# Altium schematic sheet Charging_TI.SchDoc(Charging_TI)
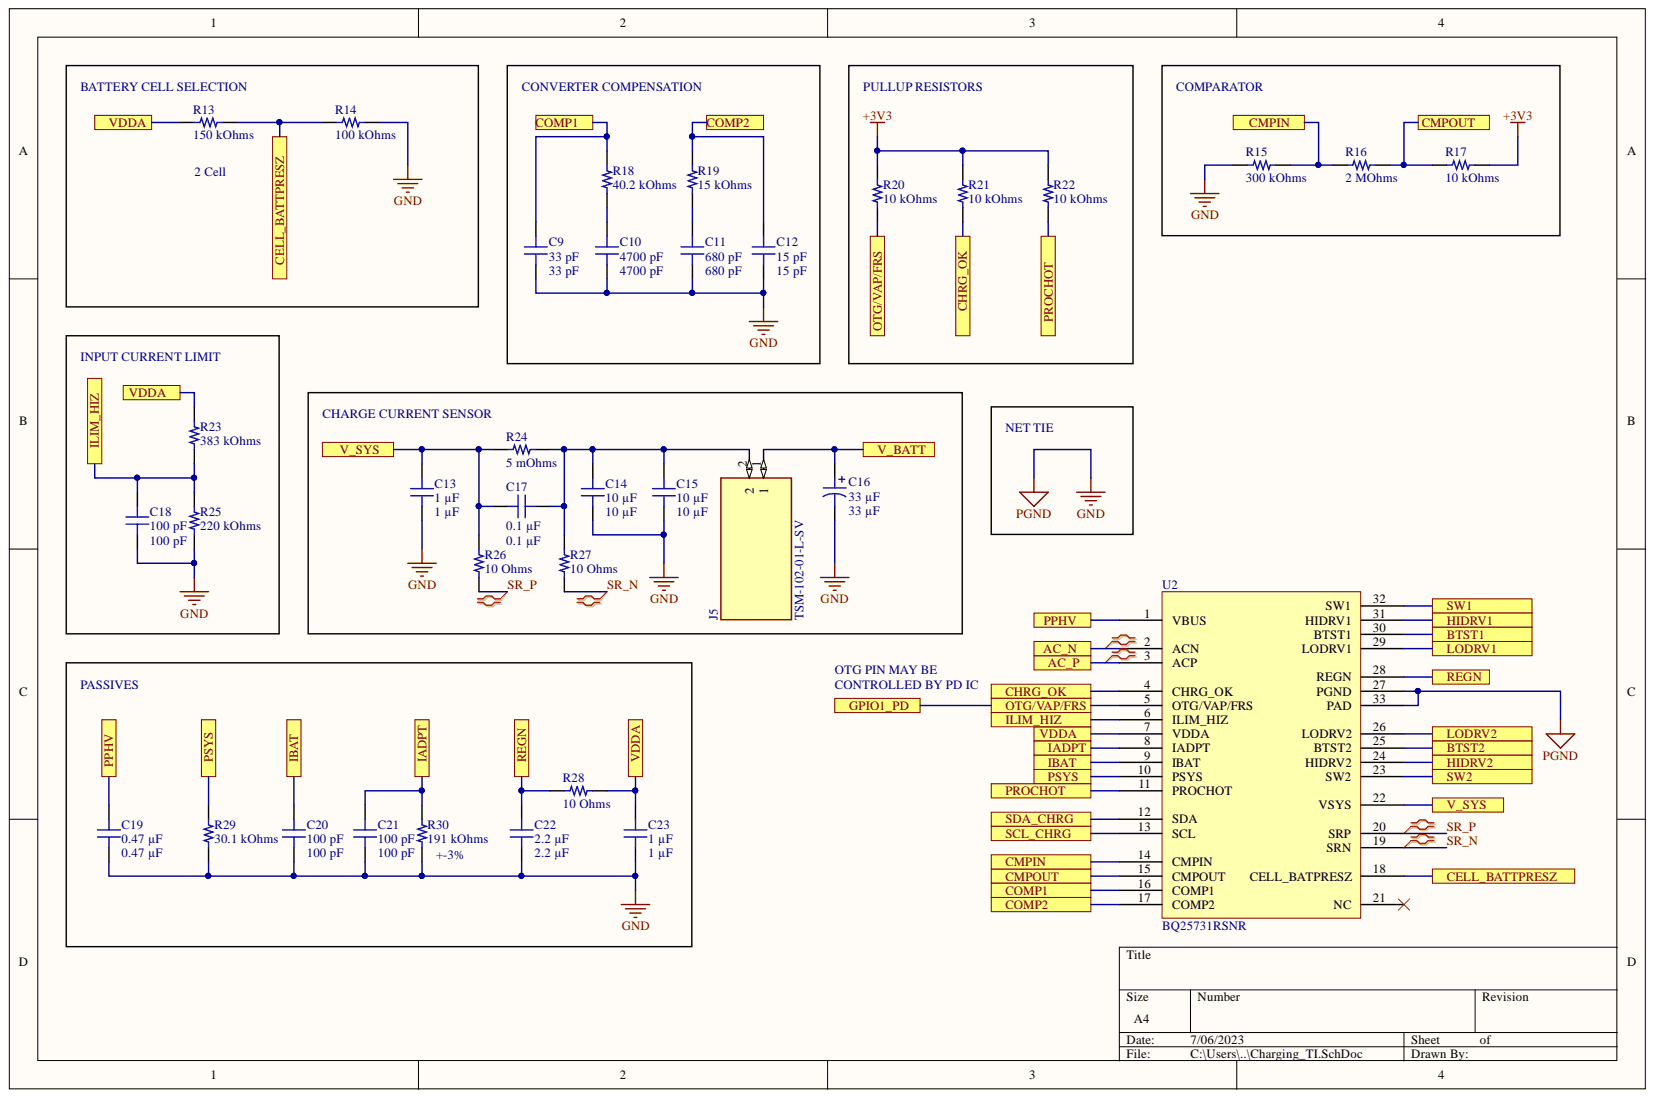
[diagram p0: Charging_TI.SchDoc]

## Parts
C9  33 pF  [CAP 0402_1005]
C10  4700 pF  [CAP 0402_1005]
C11  680 pF  [CAP 0402_1005]
C12  15 pF  [CAP 0402_1005]
C13  1 µF  [CAP 0402_1005]
C14  10 µF  [CAP 0805_2012]
C15  10 µF  [CAP 0805_2012]
C16  33 µF  [CAP POL 2917_7343]
C17  0.1 µF  [CAP 0603_1608]
C18  100 pF  [CAP 0603_1608]
C19  0.47 µF  [CAP 0805_2012]
C20  100 pF  [CAP 0603_1608]
C21  100 pF  [CAP 0603_1608]
C22  2.2 µF  [CAP 0603_1608]
C23  1 µF  [CAP 0603_1608]
J5  TSM-102-01-L-SV  [TSM-102-01-L-SV]
R13  150 kOhms  [RES 0402_1005]
R14  100 kOhms  [RES 0402_1005]
R15  300 kOhms  [RES 0402_1005]
R16  2 MOhms  [RES 0402_1005]
R17  10 kOhms  [RES 0402_1005]
R18  40.2 kOhms  [RES 0402_1005]
R19  15 kOhms  [RES 0402_1005]
R20  10 kOhms  [RES 0402_1005]
R21  10 kOhms  [RES 0402_1005]
R22  10 kOhms  [RES 0402_1005]
R23  383 kOhms  [RES 0402_1005]
R24  5 mOhms  [RES 1206_3216]
R25  220 kOhms  [RES 0402_1005]
R26  10 Ohms  [RES 0603_1608]
R27  10 Ohms  [RES 0603_1608]
R28  10 Ohms  [RES 0603_1608]
R29  30.1 kOhms  [RES 0402_1005]
R30  191 kOhms  [RES 0402_1005]
U2  BQ25731RSNR  [QFN40P400X400X80_HS-33N]

## Ports
AC_N, AC_P, BTST1, BTST2, CELL_BATTPRESZ, CHRG_OK, CMPIN, CMPOUT, COMP1, COMP2, GPIO1_PD, HIDRV1, HIDRV2, IADPT, IBAT, ILIM_HIZ, LODRV1, LODRV2, OTG/VAP/FRS, PPHV, PROCHOT, PSYS, REGN, SCL_CHRG, SDA_CHRG, SW1, SW2, V_BATT, V_SYS, VDDA

## Nets
+3V3: R17.1 R20.2 R21.2 R22.2
GND: C9.1 C10.1 C11.1 C12.1 C13.2 C14.1 C15.1 C16.2 C18.1 C19.1 C20.1 C21.1 C22.1 C23.1 R14.2 R15.1 R25.1 R29.1 R30.1 U2.27(PGND) U2.33(PAD)
AC_N: U2.2(ACN) ; port AC_N
AC_P: U2.3(ACP) ; port AC_P
BTST1: U2.30(BTST1) ; port BTST1
BTST2: U2.25(BTST2) ; port BTST2
CELL_BATTPRESZ: R13.2 R14.1 U2.18(CELL_BATPRESZ) ; port CELL_BATTPRESZ
CHRG_OK: R21.1 U2.4(CHRG_OK) ; port CHRG_OK
CMPIN: R15.2 R16.1 U2.14(CMPIN) ; port CMPIN
CMPOUT: R16.2 R17.2 U2.15(CMPOUT) ; port CMPOUT
COMP1: C9.2 R18.2 U2.16(COMP1) ; port COMP1
COMP2: C12.2 R19.2 U2.17(COMP2) ; port COMP2
GPIO1_PD: R20.1 U2.5(OTG/VAP/FRS) ; port GPIO1_PD, port OTG/VAP/FRS
HIDRV1: U2.31(HIDRV1) ; port HIDRV1
HIDRV2: U2.24(HIDRV2) ; port HIDRV2
IADPT: C21.2 R30.2 U2.8(IADPT) ; port IADPT
IBAT: C20.2 U2.9(IBAT) ; port IBAT
ILIM_HIZ: C18.2 R23.1 R25.2 U2.6(ILIM_HIZ) ; port ILIM_HIZ
LODRV1: U2.29(LODRV1) ; port LODRV1
LODRV2: U2.26(LODRV2) ; port LODRV2
PPHV: C19.2 U2.1(VBUS) ; port PPHV
PROCHOT: R22.1 U2.11(PROCHOT) ; port PROCHOT
PSYS: R29.2 U2.10(PSYS) ; port PSYS
REGN: C22.2 R28.1 U2.28(REGN) ; port REGN
SCL_CHRG: U2.13(SCL) ; port SCL_CHRG
SDA_CHRG: U2.12(SDA) ; port SDA_CHRG
SR_N: R27.1 U2.19(SRN)
SR_P: R26.1 U2.20(SRP)
SW1: U2.32(SW1) ; port SW1
SW2: U2.23(SW2) ; port SW2
V_BATT: C16.1 J5.1 ; port V_BATT
V_SYS: C13.1 C17.1 R24.1 R26.2 U2.22(VSYS) ; port V_SYS
VDDA: C23.2 R13.1 R23.2 R28.2 U2.7(VDDA) ; port VDDA
NetC10_2: C10.2 R18.1
NetC11_2: C11.2 R19.1
NetC14_2: C14.2 C15.2 C17.2 J5.2 R24.2 R27.2

Not connected: U2 21(NC)

## Library data of the parts
C9 = UMK105CH330JVHF: CAP CER 33PF 50V CH 0402; manufacturer: Taiyo Yuden; Tolerance: ±5%; Database Table Name: Capacitors - Ceramic - 0402; Voltage - Rated: 50V; Value: 33 pF; Temperature Coefficient: CH; Supplier Part Number 1: 587-5079-1-ND; Supplier 1: DigiKey; Size / Dimension: 0.039" L x 0.020" W (1.00mm x 0.50mm); series: M; Ratings: AEC-Q200; price: 0.01; Part Status: Active; Part Number: UMK105CH330JVHF; partid: 1883; packaging: TapeAndReel; Package / Case: 0402 (1005 Metric); Mounting Type: Surface Mount, MLCC; Minimum Order: 1; Lifecycle Status: Active; Library Name: Celestial Altium Library - altium_library.DbLib; lastupdated: 14/08/2022 9:05:56 PM; Device Package: 0402 (1005 Metric); componentlink2url: https://www.digikey.com/en/products/detail/taiyo-yuden/UMK105CH330JVHF/6563672; componentlink2description: DigiKey Link; Component Kind: Standard; Capacitance: 33 pF; Applications: Automotive
C10 = 0402B472J500CT: CAP CER 4700PF 50V X7R 0402; manufacturer: Walsin Technology Corporation; Tolerance: ±5%; Database Table Name: Capacitors - Ceramic - 0402; Voltage - Rated: 50V; Value: 4700 pF; Thickness (Max): 0.022" (0.55mm); Temperature Coefficient: X7R; Supplier Part Number 1: 1292-1210-1-ND; Supplier 1: DigiKey; Size / Dimension: 0.039" L x 0.020" W (1.00mm x 0.50mm); series: -; price: 0.01; Part Status: Active; Part Number: 0402B472J500CT; partid: 1879; packaging: TapeAndReel; Package / Case: 0402 (1005 Metric); Operating Temperature: -55°C ~ 125°C; Mounting Type: Surface Mount, MLCC; Minimum Order: 1; Lifecycle Status: Active; Library Name: Celestial Altium Library - altium_library.DbLib; lastupdated: 14/08/2022 9:05:56 PM; Device Package: 0402 (1005 Metric); componentlink2url: https://www.digikey.com/en/products/detail/walsin-technology-corporation/0402B472J500CT/9354708; componentlink2description: DigiKey Link; componentlink1url: http://www.passivecomponent.com/wp-content/uploads/2018/10/MLCC.pdf; componentlink1description: Datasheet; Component Kind: Standard; Capacitance: 4700 pF; Applications: General Purpose
C11 = C1005NP01H681J050BA: CAP CER 680PF 50V NP0 0402; manufacturer: TDK Corporation; Tolerance: ±5%; Temperature Coefficient: C0G, NP0; Database Table Name: Capacitors - Ceramic - 0402; Voltage - Rated: 50V; Value: 680 pF; Thickness (Max): 0.022" (0.55mm); Supplier Part Number 1: 445-13809-1-ND; Supplier 1: DigiKey; Size / Dimension: 0.039" L x 0.020" W (1.00mm x 0.50mm); series: C; price: 0.02; Part Status: Active; Part Number: C1005NP01H681J050BA; partid: 1790; packaging: TapeAndReel; Package / Case: 0402 (1005 Metric); Operating Temperature: -55°C ~ 150°C; Mounting Type: Surface Mount, MLCC; Minimum Order: 1; Lifecycle Status: Active; Library Name: Celestial Altium Library - altium_library.DbLib; lastupdated: 14/08/2022 9:05:57 PM; Features: High Temperature; Device Package: 0402 (1005 Metric); componentlink2url: https://www.digikey.com/en/products/detail/tdk-corporation/C1005NP01H681J050BA/3951045; componentlink2description: DigiKey Link; componentlink1url: https://product.tdk.com/info/en/catalog/datasheets/mlcc_commercial_hightemp_en.pdf?ref_disty=digikey; componentlink1description: Datasheet; Component Kind: Standard; Capacitance: 680 pF; Applications: General Purpose
C12 = CBR04C150J5GAC: CAP CER 15PF 50V C0G/NP0 0402; manufacturer: KEMET; Tolerance: ±5%; Temperature Coefficient: C0G, NP0; Database Table Name: Capacitors - Ceramic - 0402; Voltage - Rated: 50V; Value: 15 pF; Thickness (Max): 0.022" (0.55mm); Supplier Part Number 1: 399-6170-1-ND; Supplier 1: DigiKey; Size / Dimension: 0.039" L x 0.020" W (1.00mm x 0.50mm); series: CBR-SMD RF C0G; price: 0.02; Part Status: Active; Part Number: CBR04C150J5GAC; partid: 1728; packaging: TapeAndReel; Package / Case: 0402 (1005 Metric); Operating Temperature: -55°C ~ 125°C; Mounting Type: Surface Mount, MLCC; Minimum Order: 1; Lifecycle Status: Active; Library Name: Celestial Altium Library - altium_library.DbLib; lastupdated: 14/08/2022 9:05:58 PM; Features: High Q, Low Loss, Ultra Low ESR; Device Package: 0402 (1005 Metric); componentlink2url: https://www.digikey.com/en/products/detail/kemet/CBR04C150J5GAC/2732287; componentlink2description: DigiKey Link; componentlink1url: https://api.kemet.com/component-edge/download/datasheet/CBR04C150J5GAC.pdf; componentlink1description: Datasheet; Component Kind: Standard; Capacitance: 15 pF; Applications: RF, Microwave, High Frequency
C13 = EMK105BJ105MV-F: CAP CER 1UF 16V X5R 0402; manufacturer: Taiyo Yuden; Tolerance: ±20%; Database Table Name: Capacitors - Ceramic - 0402; Voltage - Rated: 16V; Value: 1 µF; Thickness (Max): 0.022" (0.55mm); Temperature Coefficient: X5R; Supplier Part Number 1: 587-5514-1-ND; Supplier 1: DigiKey; Size / Dimension: 0.039" L x 0.020" W (1.00mm x 0.50mm); series: M; price: 0.01; Part Status: Active; Part Number: EMK105BJ105MV-F; partid: 1933; packaging: TapeAndReel; Package / Case: 0402 (1005 Metric); Operating Temperature: -55°C ~ 85°C; Mounting Type: Surface Mount, MLCC; Minimum Order: 1; Lifecycle Status: Active; Library Name: Celestial Altium Library - altium_library.DbLib; lastupdated: 5/04/2023 7:05:02 PM; Device Package: 0402 (1005 Metric); componentlink2url: https://www.digikey.com/en/products/detail/taiyo-yuden/EMK105BJ105MV-F/4970641; componentlink2description: DigiKey Link; componentlink1url: https://ds.yuden.co.jp/TYCOMPAS/ut/detail?pn=EMK105BJ105MV-F  &u=M; componentlink1description: Datasheet; Component Kind: Standard; Capacitance: 1 µF; Applications: SMPS Filtering
C14 C15 = GRM21BR61H106KE43L: CAP CER 10UF 50V X5R 0805; manufacturer: Murata Electronics; Tolerance: ±10%; Database Table Name: Capacitors - Ceramic - 0805; Voltage - Rated: 50V; Value: 10 µF; Thickness (Max): 0.057" (1.45mm); Temperature Coefficient: X5R; Supplier Part Number 1: 490-18663-1-ND; Supplier 1: DigiKey; Size / Dimension: 0.079" L x 0.049" W (2.00mm x 1.25mm); series: GRM; price: 0.07; Part Status: Active; Part Number: GRM21BR61H106KE43L; partid: 39284; packaging: TapeAndReel; Package / Case: 0805 (2012 Metric); Operating Temperature: -55°C ~ 85°C; Mounting Type: Surface Mount, MLCC; Minimum Order: 1; Lifecycle Status: Active; Library Name: Celestial Altium Library - altium_library.DbLib; lastupdated: 14/08/2022 9:12:57 PM; Device Package: 0805 (2012 Metric); componentlink2url: https://www.digikey.com/en/products/detail/murata-electronics/GRM21BR61H106KE43L/10326316; componentlink2description: DigiKey Link; componentlink1url: https://search.murata.co.jp/Ceramy/image/img/A01X/G101/ENG/GRM21BR61H106KE43-01A.pdf; componentlink1description: Datasheet; Component Kind: Standard; Capacitance: 10 µF; Applications: General Purpose
C16 = T495X336M035ATE175: CAP TANT 33UF 20% 35V 2917; Manufacturer Size Code: X; manufacturer: KEMET; Type: Molded; Lifetime @ Temp.: 2000 Hrs @ 85°C; ESR (Equivalent Series Resistance): 175mOhm; Database Table Name: Capacitors - Tantalum; Voltage - Rated: 35 V; Value: 33 µF; Tolerance: ±20%; Supplier Part Number 1: 399-5266-1-ND; Supplier 1: DigiKey; Size / Dimension: 0.287" L x 0.169" W (7.30mm x 4.30mm); series: T495; price: 1.07; Part Status: Active; Part Number: T495X336M035ATE175; partid: 698; packaging: TapeAndReel; Package / Case: 2917 (7343 Metric); Operating Temperature: -55°C ~ 125°C; Mounting Type: Surface Mount; Minimum Order: 1; Lifecycle Status: Active; Library Name: Celestial Altium Library - altium_library.DbLib; lastupdated: 14/08/2022 9:47:02 PM; Height - Seated (Max): 0.169" (4.30mm); Features: General Purpose; Device Package: 2917 (7343 Metric); componentlink2url: https://www.digikey.com/en/products/detail/kemet/T495X336M035ATE175/1833502; componentlink2description: DigiKey Link; componentlink1url: https://api.kemet.com/component-edge/download/datasheet/T495X336M035ATE175.pdf; componentlink1description: Datasheet; Component Kind: Standard; Capacitance: 33 µF
C17 = C0603C104K5RAC7411: CAP CER 0.1UF 50V X7R 0603; manufacturer: KEMET; Tolerance: ±10%; Database Table Name: Capacitors - Ceramic - 0603; Voltage - Rated: 50V; Value: 0.1 µF; Thickness (Max): 0.035" (0.90mm); Temperature Coefficient: X7R; Supplier Part Number 1: 399-7374-2-ND; Supplier 1: DigiKey; Size / Dimension: 0.063" L x 0.031" W (1.60mm x 0.80mm); series: SMD Comm X7R; price: 0.01; Part Status: Active; Part Number: C0603C104K5RAC7411; partid: 2233; packaging: TapeAndReel; Package / Case: 0603 (1608 Metric); Operating Temperature: -55°C ~ 125°C; Mounting Type: Surface Mount, MLCC; Minimum Order: 15000; Lifecycle Status: Active; Library Name: Celestial Altium Library - altium_library.DbLib; lastupdated: 14/08/2022 9:09:47 PM; Device Package: 0603 (1608 Metric); componentlink2url: https://www.digikey.com/en/products/detail/kemet/C0603C104K5RAC7411/3317013; componentlink2description: DigiKey Link; componentlink1url: https://api.kemet.com/component-edge/download/datasheet/C0603C104K5RAC7411.pdf; componentlink1description: Datasheet; Component Kind: Standard; Capacitance: 0.1 µF; Applications: Bypass, Decoupling
C18 C20 C21 = C0603C101K5GACAUTO: CAP CER 100PF 50V C0G/NP0 0603; manufacturer: KEMET; Tolerance: ±10%; Temperature Coefficient: C0G, NP0; Database Table Name: Capacitors - Ceramic - 0603; Voltage - Rated: 50V; Value: 100 pF; Thickness (Max): 0.034" (0.87mm); Supplier Part Number 1: 399-6843-1-ND; Supplier 1: DigiKey; Size / Dimension: 0.063" L x 0.031" W (1.60mm x 0.80mm); series: SMD Auto C0G; Ratings: AEC-Q200; price: 0.01; Part Status: Active; Part Number: C0603C101K5GACAUTO; partid: 2201; packaging: TapeAndReel; Package / Case: 0603 (1608 Metric); Operating Temperature: -55°C ~ 125°C; Mounting Type: Surface Mount, MLCC; Minimum Order: 1; Lifecycle Status: Active; Library Name: Celestial Altium Library - altium_library.DbLib; lastupdated: 14/08/2022 9:09:47 PM; Features: Low ESL; Device Package: 0603 (1608 Metric); componentlink2url: https://www.digikey.com/en/products/detail/kemet/C0603C101K5GACAUTO/3314842; componentlink2description: DigiKey Link; componentlink1url: https://api.kemet.com/component-edge/download/datasheet/C0603C101K5GACAUTO.pdf; componentlink1description: Datasheet; Component Kind: Standard; Capacitance: 100 pF; Applications: Automotive
C19 = C0805C474K5RACAUTO: CAP CER 0.47UF 50V X7R 0805; manufacturer: KEMET; Tolerance: ±10%; Database Table Name: Capacitors - Ceramic - 0805; Voltage - Rated: 50V; Value: 0.47 µF; Thickness (Max): 0.043" (1.10mm); Temperature Coefficient: X7R; Supplier Part Number 1: 399-6976-1-ND; Supplier 1: DigiKey; Size / Dimension: 0.079" L x 0.049" W (2.00mm x 1.25mm); series: SMD Auto X7R; Ratings: AEC-Q200; price: 0.06; Part Status: Active; Part Number: C0805C474K5RACAUTO; partid: 2727; packaging: TapeAndReel; Package / Case: 0805 (2012 Metric); Operating Temperature: -55°C ~ 125°C; Mounting Type: Surface Mount, MLCC; Minimum Order: 1; Lifecycle Status: Active; Library Name: Celestial Altium Library - altium_library.DbLib; lastupdated: 14/08/2022 9:13:14 PM; Device Package: 0805 (2012 Metric); componentlink2url: https://www.digikey.com/en/products/detail/kemet/C0805C474K5RACAUTO/3314969; componentlink2description: DigiKey Link; componentlink1url: https://api.kemet.com/component-edge/download/datasheet/C0805C474K5RACAUTO.pdf; componentlink1description: Datasheet; Component Kind: Standard; Capacitance: 0.47 µF; Applications: Automotive, Bypass, Decoupling
C22 = GRM188R6YA225KA12D: CAP CER 2.2UF 35V X5R 0603; manufacturer: Murata Electronics; Tolerance: ±10%; Database Table Name: Capacitors - Ceramic - 0603; Voltage - Rated: 35V; Value: 2.2 µF; Thickness (Max): 0.035" (0.90mm); Temperature Coefficient: X5R; Supplier Part Number 1: 490-7204-1-ND; Supplier 1: DigiKey; Size / Dimension: 0.063" L x 0.031" W (1.60mm x 0.80mm); series: GRM; price: 0.03; Part Status: Active; Part Number: GRM188R6YA225KA12D; partid: 11175; packaging: TapeAndReel; Package / Case: 0603 (1608 Metric); Operating Temperature: -55°C ~ 85°C; Mounting Type: Surface Mount, MLCC; Minimum Order: 1; Lifecycle Status: Active; Library Name: Celestial Altium Library - altium_library.DbLib; lastupdated: 14/08/2022 9:09:23 PM; Device Package: 0603 (1608 Metric); componentlink2url: https://www.digikey.com/en/products/detail/murata-electronics/GRM188R6YA225KA12D/3900462; componentlink2description: DigiKey Link; componentlink1url: https://www.murata.com/~/media/webrenewal/support/library/catalog/products/capacitor/mlcc/c02e.ashx?la=en-us; componentlink1description: Datasheet; Component Kind: Standard; Capacitance: 2.2 µF; Applications: General Purpose
C23 = GMJ107CB7105KAHR: CAP CER 1UF 35V X7R 0603; manufacturer: Taiyo Yuden; Tolerance: ±10%; Database Table Name: Capacitors - Ceramic - 0603; Voltage - Rated: 35V; Value: 1 µF; Thickness (Max): 0.035" (0.90mm); Temperature Coefficient: X7R; Supplier Part Number 1: 587-4356-1-ND; Supplier 1: DigiKey; Size / Dimension: 0.063" L x 0.031" W (1.60mm x 0.80mm); series: M; Ratings: AEC-Q200; price: 0.06; Part Status: Active; Part Number: GMJ107CB7105KAHR; partid: 5874; packaging: TapeAndReel; Package / Case: 0603 (1608 Metric); Operating Temperature: -55°C ~ 125°C; Mounting Type: Surface Mount, MLCC; Minimum Order: 1; Lifecycle Status: Active; Library Name: Celestial Altium Library - altium_library.DbLib; lastupdated: 14/08/2022 9:09:37 PM; Features: Soft Termination; Device Package: 0603 (1608 Metric); componentlink2url: https://www.digikey.com/en/products/detail/taiyo-yuden/GMJ107CB7105KAHR/5405535; componentlink2description: DigiKey Link; componentlink1url: https://www.yuden.co.jp/productdata/catalog//mlcc11_hq_e.pdf; componentlink1description: Datasheet; Component Kind: Standard; Capacitance: 1 µF; Applications: Automotive, Boardflex Sensitive
J5 = TSM-102-01-L-SV: MF: {'manufacturer': u'Samtec Inc.'}; Price: None; Package: None; MP: TSM-102-01-L-SV; Component Kind: Standard; Availability: Unavailable
R13 = RC0402JR-07150KL: RES 150K OHM 5% 1/16W 0402; Manufacturer: Yageo; Temperature Coefficient: ±100ppm/°C; Power (Watts): 0.063W, 1/16W; Database Table Name: Resistors - Surface Mount - 0402; Value: 150 kOhms; Tolerance: ±5%; Supplier Part Number 1: 311-150KJRCT-ND; Supplier Device Package: 0402; Supplier 1: DigiKey; Size / Dimension: 0.039" L x 0.020" W (1.00mm x 0.50mm); Series: RC_L; Resistance: 150 kOhms; Price: 0.01; Part Status: Active; Part Number: RC0402JR-07150KL; PartId: 4824; Packaging: CutTape; Package / Case: 0402 (1005 Metric); Operating Temperature: -55°C ~ 155°C; Number of Terminations: 2; Minimum Order: 1; Lifecycle Status: Active; Library Name: Celestial Altium Library - altium_library.DbLib; LastUpdated: 4/04/2023 7:23:01 PM; Height - Seated (Max): 0.016" (0.40mm); Features: Moisture Resistant; Device Package: 0402 (1005 Metric); Composition: Thick Film; ComponentLink2URL: https://www.digikey.com/en/products/detail/yageo/RC0402JR-07150KL/726425; ComponentLink2Description: DigiKey Link; ComponentLink1URL: https://www.yageo.com/upload/media/product/productsearch/datasheet/rchip/PYu-RC_Group_51_RoHS_L_10.pdf; ComponentLink1Description: Datasheet; Component Kind: Standard
R14 = RT0402FRE07100KL: RES SMD 100K OHM 1% 1/16W 0402; Manufacturer: Yageo; Temperature Coefficient: ±50ppm/°C; Power (Watts): 0.063W, 1/16W; Database Table Name: Resistors - Surface Mount - 0402; Value: 100 kOhms; Tolerance: ±1%; Supplier Part Number 1: YAG2307CT-ND; Supplier Device Package: 0402; Supplier 1: DigiKey; Size / Dimension: 0.039" L x 0.020" W (1.00mm x 0.50mm); Series: RT; Resistance: 100 kOhms; Price: 0.01; Part Status: Active; Part Number: RT0402FRE07100KL; PartId: 3190; Packaging: TapeAndReel; Package / Case: 0402 (1005 Metric); Operating Temperature: -55°C ~ 155°C; Number of Terminations: 2; Minimum Order: 1; Lifecycle Status: Active; Library Name: Celestial Altium Library - altium_library.DbLib; LastUpdated: 4/04/2023 7:23:08 PM; Height - Seated (Max): 0.014" (0.35mm); Device Package: 0402 (1005 Metric); Composition: Thin Film; ComponentLink2URL: https://www.digikey.com/en/products/detail/yageo/RT0402FRE07100KL/1071698; ComponentLink2Description: DigiKey Link; ComponentLink1URL: https://www.yageo.com/upload/media/product/productsearch/datasheet/rchip/PYu-RT_1-to-0.01_RoHS_L_11.pdf; ComponentLink1Description: Datasheet; Component Kind: Standard
R15 = RC0402FR-07300KL: RES 300K OHM 1% 1/16W 0402; Manufacturer: Yageo; Temperature Coefficient: ±100ppm/°C; Power (Watts): 0.063W, 1/16W; Database Table Name: Resistors - Surface Mount - 0402; Value: 300 kOhms; Tolerance: ±1%; Supplier Part Number 1: 311-300KLRCT-ND; Supplier Device Package: 0402; Supplier 1: DigiKey; Size / Dimension: 0.039" L x 0.020" W (1.00mm x 0.50mm); Series: RC_L; Resistance: 300 kOhms; Price: 0.01; Part Status: Active; Part Number: RC0402FR-07300KL; PartId: 2649; Packaging: CutTape; Package / Case: 0402 (1005 Metric); Operating Temperature: -55°C ~ 155°C; Number of Terminations: 2; Minimum Order: 1; Lifecycle Status: Active; Library Name: Celestial Altium Library - altium_library.DbLib; LastUpdated: 4/04/2023 7:23:10 PM; Height - Seated (Max): 0.016" (0.40mm); Features: Moisture Resistant; Device Package: 0402 (1005 Metric); Composition: Thick Film; ComponentLink2URL: https://www.digikey.com/en/products/detail/yageo/RC0402FR-07300KL/726588; ComponentLink2Description: DigiKey Link; ComponentLink1URL: https://www.yageo.com/upload/media/product/productsearch/datasheet/rchip/PYu-RC_Group_51_RoHS_L_10.pdf; ComponentLink1Description: Datasheet; Component Kind: Standard
R16 = RMCF0402JT2M00: RES 2M OHM 5% 1/16W 0402; Manufacturer: Stackpole Electronics Inc; Temperature Coefficient: ±200ppm/°C; Power (Watts): 0.063W, 1/16W; Database Table Name: Resistors - Surface Mount - 0402; Value: 2 MOhms; Tolerance: ±5%; Supplier Part Number 1: 738-RMCF0402JT2M00CT-ND; Supplier Device Package: 0402; Supplier 1: DigiKey; Size / Dimension: 0.039" L x 0.020" W (1.00mm x 0.50mm); Series: RMCF; Resistance: 2 MOhms; Ratings: AEC-Q200; Price: 0.01; Part Status: Active; Part Number: RMCF0402JT2M00; PartId: 2925; Packaging: CutTape; Package / Case: 0402 (1005 Metric); Operating Temperature: -55°C ~ 155°C; Number of Terminations: 2; Minimum Order: 1; Lifecycle Status: Active; Library Name: Celestial Altium Library - altium_library.DbLib; LastUpdated: 4/04/2023 7:23:09 PM; Height - Seated (Max): 0.016" (0.40mm); Features: Automotive AEC-Q200; Device Package: 0402 (1005 Metric); Composition: Thick Film; ComponentLink2URL: https://www.digikey.com/en/products/detail/stackpole-electronics-inc/RMCF0402JT2M00/1712425; ComponentLink2Description: DigiKey Link; ComponentLink1URL: https://www.seielect.com/catalog/sei-rmcf_rmcp.pdf; ComponentLink1Description: Datasheet; Component Kind: Standard
R17 R20-R22 = RC0402JR-1310KL: RES 10K OHM 5% 1/16W 0402; Manufacturer: Yageo; Temperature Coefficient: ±100ppm/°C; Power (Watts): 0.063W, 1/16W; Database Table Name: Resistors - Surface Mount - 0402; Value: 10 kOhms; Tolerance: ±5%; Supplier Part Number 1: 13-RC0402JR-1310KLTR-ND; Supplier Device Package: 0402; Supplier 1: DigiKey; Size / Dimension: 0.039" L x 0.020" W (1.00mm x 0.50mm); Series: RC_L; Resistance: 10 kOhms; Price: 0; Part Status: Active; Part Number: RC0402JR-1310KL; PartId: 69237; Package / Case: 0402 (1005 Metric); Operating Temperature: -55°C ~ 155°C; Number of Terminations: 2; Minimum Order: 50000; Lifecycle Status: Active; Library Name: Celestial Altium Library - altium_library.DbLib; LastUpdated: 4/04/2023 7:21:31 PM; Height - Seated (Max): 0.016" (0.40mm); Features: Moisture Resistant; Device Package: 0402 (1005 Metric); Composition: Thick Film; ComponentLink2URL: https://www.digikey.com/en/products/detail/yageo/RC0402JR-1310KL/13694115; ComponentLink2Description: DigiKey Link; ComponentLink1URL: https://www.yageo.com/upload/media/product/productsearch/datasheet/rchip/PYu-RC_Group_51_RoHS_L_11.pdf; ComponentLink1Description: Datasheet; Component Kind: Standard
R18 = RC0402FR-0740K2L: RES 40.2K OHM 1% 1/16W 0402; Manufacturer: Yageo; Temperature Coefficient: ±100ppm/°C; Power (Watts): 0.063W, 1/16W; Database Table Name: Resistors - Surface Mount - 0402; Value: 40.2 kOhms; Tolerance: ±1%; Supplier Part Number 1: 311-40.2KLRCT-ND; Supplier Device Package: 0402; Supplier 1: DigiKey; Size / Dimension: 0.039" L x 0.020" W (1.00mm x 0.50mm); Series: RC_L; Resistance: 40.2 kOhms; Price: 0.01; Part Status: Active; Part Number: RC0402FR-0740K2L; PartId: 2526; Packaging: CutTape; Package / Case: 0402 (1005 Metric); Operating Temperature: -55°C ~ 155°C; Number of Terminations: 2; Minimum Order: 1; Lifecycle Status: Active; Library Name: Celestial Altium Library - altium_library.DbLib; LastUpdated: 14/08/2022 9:24:17 PM; Height - Seated (Max): 0.016" (0.40mm); Features: Moisture Resistant; Device Package: 0402 (1005 Metric); Composition: Thick Film; ComponentLink2URL: https://www.digikey.com/en/products/detail/yageo/RC0402FR-0740K2L/726607; ComponentLink2Description: DigiKey Link; ComponentLink1URL: https://www.yageo.com/upload/media/product/productsearch/datasheet/rchip/PYu-RC_Group_51_RoHS_L_10.pdf; ComponentLink1Description: Datasheet; Component Kind: Standard
R19 = RC0402FR-1315KL: RES 15K OHM 1% 1/16W 0402; Manufacturer: Yageo; Temperature Coefficient: ±100ppm/°C; Power (Watts): 0.063W, 1/16W; Database Table Name: Resistors - Surface Mount - 0402; Value: 15 kOhms; Tolerance: ±1%; Supplier Part Number 1: 13-RC0402FR-1315KLTR-ND; Supplier Device Package: 0402; Supplier 1: DigiKey; Size / Dimension: 0.039" L x 0.020" W (1.00mm x 0.50mm); Series: RC_L; Resistance: 15 kOhms; Price: 0; Part Status: Active; Part Number: RC0402FR-1315KL; PartId: 65094; Package / Case: 0402 (1005 Metric); Operating Temperature: -55°C ~ 155°C; Number of Terminations: 2; Minimum Order: 50000; Lifecycle Status: Active; Library Name: Celestial Altium Library - altium_library.DbLib; LastUpdated: 14/08/2022 9:22:52 PM; Height - Seated (Max): 0.016" (0.40mm); Features: Moisture Resistant; Device Package: 0402 (1005 Metric); Composition: Thick Film; ComponentLink2URL: https://www.digikey.com/en/products/detail/yageo/RC0402FR-1315KL/13694190; ComponentLink2Description: DigiKey Link; ComponentLink1URL: https://www.yageo.com/upload/media/product/productsearch/datasheet/rchip/PYu-RC_Group_51_RoHS_L_11.pdf; ComponentLink1Description: Datasheet; Component Kind: Standard
R23 = ERJ-2RKF3833X: RES SMD 383K OHM 1% 1/10W 0402; Manufacturer: Panasonic Electronic Components; Temperature Coefficient: ±100ppm/°C; Power (Watts): 0.1W, 1/10W; Database Table Name: Resistors - Surface Mount - 0402; Value: 383 kOhms; Tolerance: ±1%; Supplier Part Number 1: P383KLCT-ND; Supplier Device Package: 0402; Supplier 1: DigiKey; Size / Dimension: 0.039" L x 0.020" W (1.00mm x 0.50mm); Series: ERJ-2RK; Resistance: 383 kOhms; Ratings: AEC-Q200; Price: 0.01; Part Status: Active; Part Number: ERJ-2RKF3833X; PartId: 3860; Packaging: CutTape; Package / Case: 0402 (1005 Metric); Operating Temperature: -55°C ~ 155°C; Number of Terminations: 2; Minimum Order: 1; Lifecycle Status: Active; Library Name: Celestial Altium Library - altium_library.DbLib; LastUpdated: 4/04/2023 7:23:06 PM; Height - Seated (Max): 0.016" (0.40mm); Features: Automotive AEC-Q200; Device Package: 0402 (1005 Metric); Composition: Thick Film; ComponentLink2URL: https://www.digikey.com/en/products/detail/panasonic-electronic-components/ERJ-2RKF3833X/192331; ComponentLink2Description: DigiKey Link; ComponentLink1URL: https://industrial.panasonic.com/cdbs/www-data/pdf/RDA0000/AOA0000C304.pdf; ComponentLink1Description: Datasheet; Component Kind: Standard
R24 = PMR18EZPJU5L0: RES 0.005 OHM 5% 1W 1206; Manufacturer: Rohm Semiconductor; Temperature Coefficient: ±100ppm/°C; Database Table Name: Resistors - Surface Mount - 1206; Value: 5 mOhms; Tolerance: ±5%; Supplier Part Number 1: RHM.005AMCT-ND; Supplier Device Package: 1206; Supplier 1: DigiKey; Size / Dimension: 0.126" L x 0.063" W (3.20mm x 1.60mm); Series: PMR; Resistance: 5 mOhms; Ratings: AEC-Q200; Price: 0.11; Power (Watts): 1W; Part Status: Active; Part Number: PMR18EZPJU5L0; PartId: 27011; Packaging: TapeAndReel; Package / Case: 1206 (3216 Metric); Operating Temperature: -55°C ~ 155°C; Number of Terminations: 2; Minimum Order: 1; Lifecycle Status: Active; Library Name: Celestial Altium Library - altium_library.DbLib; LastUpdated: 14/08/2022 9:38:16 PM; Height - Seated (Max): 0.017" (0.43mm); Features: Automotive AEC-Q200, Current Sense; Device Package: 1206 (3216 Metric); Composition: Metal Element; ComponentLink2URL: https://www.digikey.com/en/products/detail/rohm-semiconductor/PMR18EZPJU5L0/2094212; ComponentLink2Description: DigiKey Link; ComponentLink1URL: https://www.rohm.com/datasheet/PMR18EZPFV/pmr-e; ComponentLink1Description: Datasheet; Component Kind: Standard
R25 = RC0402FR-07220KL: RES 220K OHM 1% 1/16W 0402; Manufacturer: Yageo; Temperature Coefficient: ±100ppm/°C; Power (Watts): 0.063W, 1/16W; Database Table Name: Resistors - Surface Mount - 0402; Value: 220 kOhms; Tolerance: ±1%; Supplier Part Number 1: 311-220KLRCT-ND; Supplier Device Package: 0402; Supplier 1: DigiKey; Size / Dimension: 0.039" L x 0.020" W (1.00mm x 0.50mm); Series: RC_L; Resistance: 220 kOhms; Price: 0.01; Part Status: Active; Part Number: RC0402FR-07220KL; PartId: 2589; Packaging: CutTape; Package / Case: 0402 (1005 Metric); Operating Temperature: -55°C ~ 155°C; Number of Terminations: 2; Minimum Order: 1; Lifecycle Status: Active; Library Name: Celestial Altium Library - altium_library.DbLib; LastUpdated: 4/04/2023 7:23:10 PM; Height - Seated (Max): 0.016" (0.40mm); Features: Moisture Resistant; Device Package: 0402 (1005 Metric); Composition: Thick Film; ComponentLink2URL: https://www.digikey.com/en/products/detail/yageo/RC0402FR-07220KL/726564; ComponentLink2Description: DigiKey Link; ComponentLink1URL: https://www.yageo.com/upload/media/product/productsearch/datasheet/rchip/PYu-RC_Group_51_RoHS_L_10.pdf; ComponentLink1Description: Datasheet; Component Kind: Standard
R26-R28 = ERJ-3EKF10R0V: RES SMD 10 OHM 1% 1/10W 0603; Manufacturer: Panasonic Electronic Components; Temperature Coefficient: ±100ppm/°C; Power (Watts): 0.1W, 1/10W; Database Table Name: Resistors - Surface Mount - 0603; Value: 10 Ohms; Tolerance: ±1%; Supplier Part Number 1: P10.0HCT-ND; Supplier Device Package: 0603; Supplier 1: DigiKey; Size / Dimension: 0.063" L x 0.031" W (1.60mm x 0.80mm); Series: ERJ-3EK; Resistance: 10 Ohms; Ratings: AEC-Q200; Price: 0.01; Part Status: Active; Part Number: ERJ-3EKF10R0V; PartId: 5647; Packaging: TapeAndReel; Package / Case: 0603 (1608 Metric); Operating Temperature: -55°C ~ 155°C; Number of Terminations: 2; Minimum Order: 1; Lifecycle Status: Active; Library Name: Celestial Altium Library - altium_library.DbLib; LastUpdated: 14/08/2022 9:31:25 PM; Height - Seated (Max): 0.022" (0.55mm); Features: Automotive AEC-Q200; Device Package: 0603 (1608 Metric); Composition: Thick Film; ComponentLink2URL: https://www.digikey.com/en/products/detail/panasonic-electronic-components/ERJ-3EKF10R0V/196065; ComponentLink2Description: DigiKey Link; ComponentLink1URL: https://industrial.panasonic.com/cdbs/www-data/pdf/RDA0000/AOA0000C304.pdf; ComponentLink1Description: Datasheet; Component Kind: Standard
R29 = RC0402FR-0730K1L: RES 30.1K OHM 1% 1/16W 0402; Manufacturer: Yageo; Temperature Coefficient: ±100ppm/°C; Power (Watts): 0.063W, 1/16W; Database Table Name: Resistors - Surface Mount - 0402; Value: 30.1 kOhms; Tolerance: ±1%; Supplier Part Number 1: 311-30.1KLRCT-ND; Supplier Device Package: 0402; Supplier 1: DigiKey; Size / Dimension: 0.039" L x 0.020" W (1.00mm x 0.50mm); Series: RC_L; Resistance: 30.1 kOhms; Price: 0.01; Part Status: Active; Part Number: RC0402FR-0730K1L; PartId: 2546; Packaging: CutTape; Package / Case: 0402 (1005 Metric); Operating Temperature: -55°C ~ 155°C; Number of Terminations: 2; Minimum Order: 1; Lifecycle Status: Active; Library Name: Celestial Altium Library - altium_library.DbLib; LastUpdated: 4/04/2023 7:23:11 PM; Height - Seated (Max): 0.016" (0.40mm); Features: Moisture Resistant; Device Package: 0402 (1005 Metric); Composition: Thick Film; ComponentLink2URL: https://www.digikey.com/en/products/detail/yageo/RC0402FR-0730K1L/726587; ComponentLink2Description: DigiKey Link; ComponentLink1URL: https://www.yageo.com/upload/media/product/productsearch/datasheet/rchip/PYu-RC_Group_51_RoHS_L_10.pdf; ComponentLink1Description: Datasheet; Component Kind: Standard
R30 = ERJ-2RKF1913X: RES SMD 191K OHM 1% 1/10W 0402; Manufacturer: Panasonic Electronic Components; Temperature Coefficient: ±100ppm/°C; Power (Watts): 0.1W, 1/10W; Database Table Name: Resistors - Surface Mount - 0402; Value: 191 kOhms; Tolerance: ±1%; Supplier Part Number 1: P191KLCT-ND; Supplier Device Package: 0402; Supplier 1: DigiKey; Size / Dimension: 0.039" L x 0.020" W (1.00mm x 0.50mm); Series: ERJ-2RK; Resistance: 191 kOhms; Ratings: AEC-Q200; Price: 0.01; Part Status: Active; Part Number: ERJ-2RKF1913X; PartId: 3700; Packaging: CutTape; Package / Case: 0402 (1005 Metric); Operating Temperature: -55°C ~ 155°C; Number of Terminations: 2; Minimum Order: 1; Lifecycle Status: Active; Library Name: Celestial Altium Library - altium_library.DbLib; LastUpdated: 4/04/2023 7:23:06 PM; Height - Seated (Max): 0.016" (0.40mm); Features: Automotive AEC-Q200; Device Package: 0402 (1005 Metric); Composition: Thick Film; ComponentLink2URL: https://www.digikey.com/en/products/detail/panasonic-electronic-components/ERJ-2RKF1913X/192191; ComponentLink2Description: DigiKey Link; ComponentLink1URL: https://industrial.panasonic.com/cdbs/www-data/pdf/RDA0000/AOA0000C304.pdf; ComponentLink1Description: Datasheet; Component Kind: Standard
U2 = BQ25731RSNR: I2C 1- to 5-Cell Buck-Boost Battery Charge Controller with USB-C PD 3.0 OTG Output; Library Name: Schlib2.SchLib; Component Kind: Standard
